# Altium schematic sheet USB Hub IC.SchDoc("USB Hub IC")
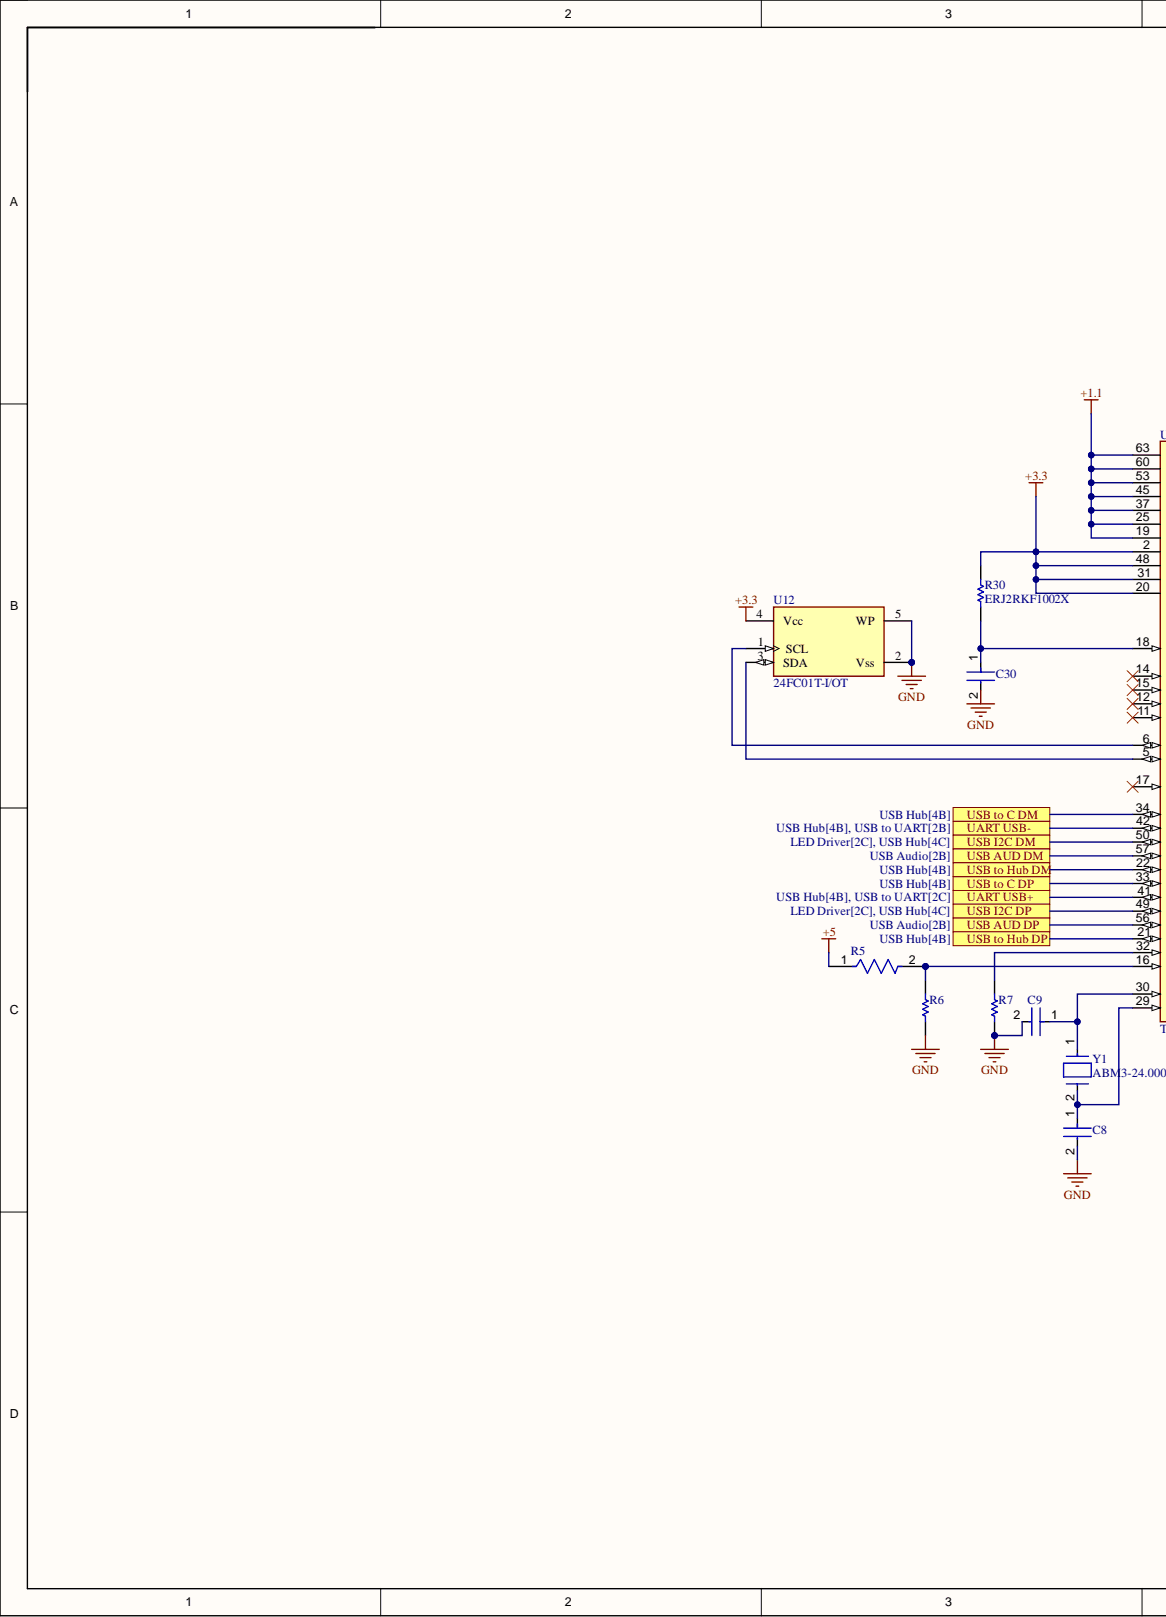
[diagram p0: USB Hub IC.SchDoc, left]
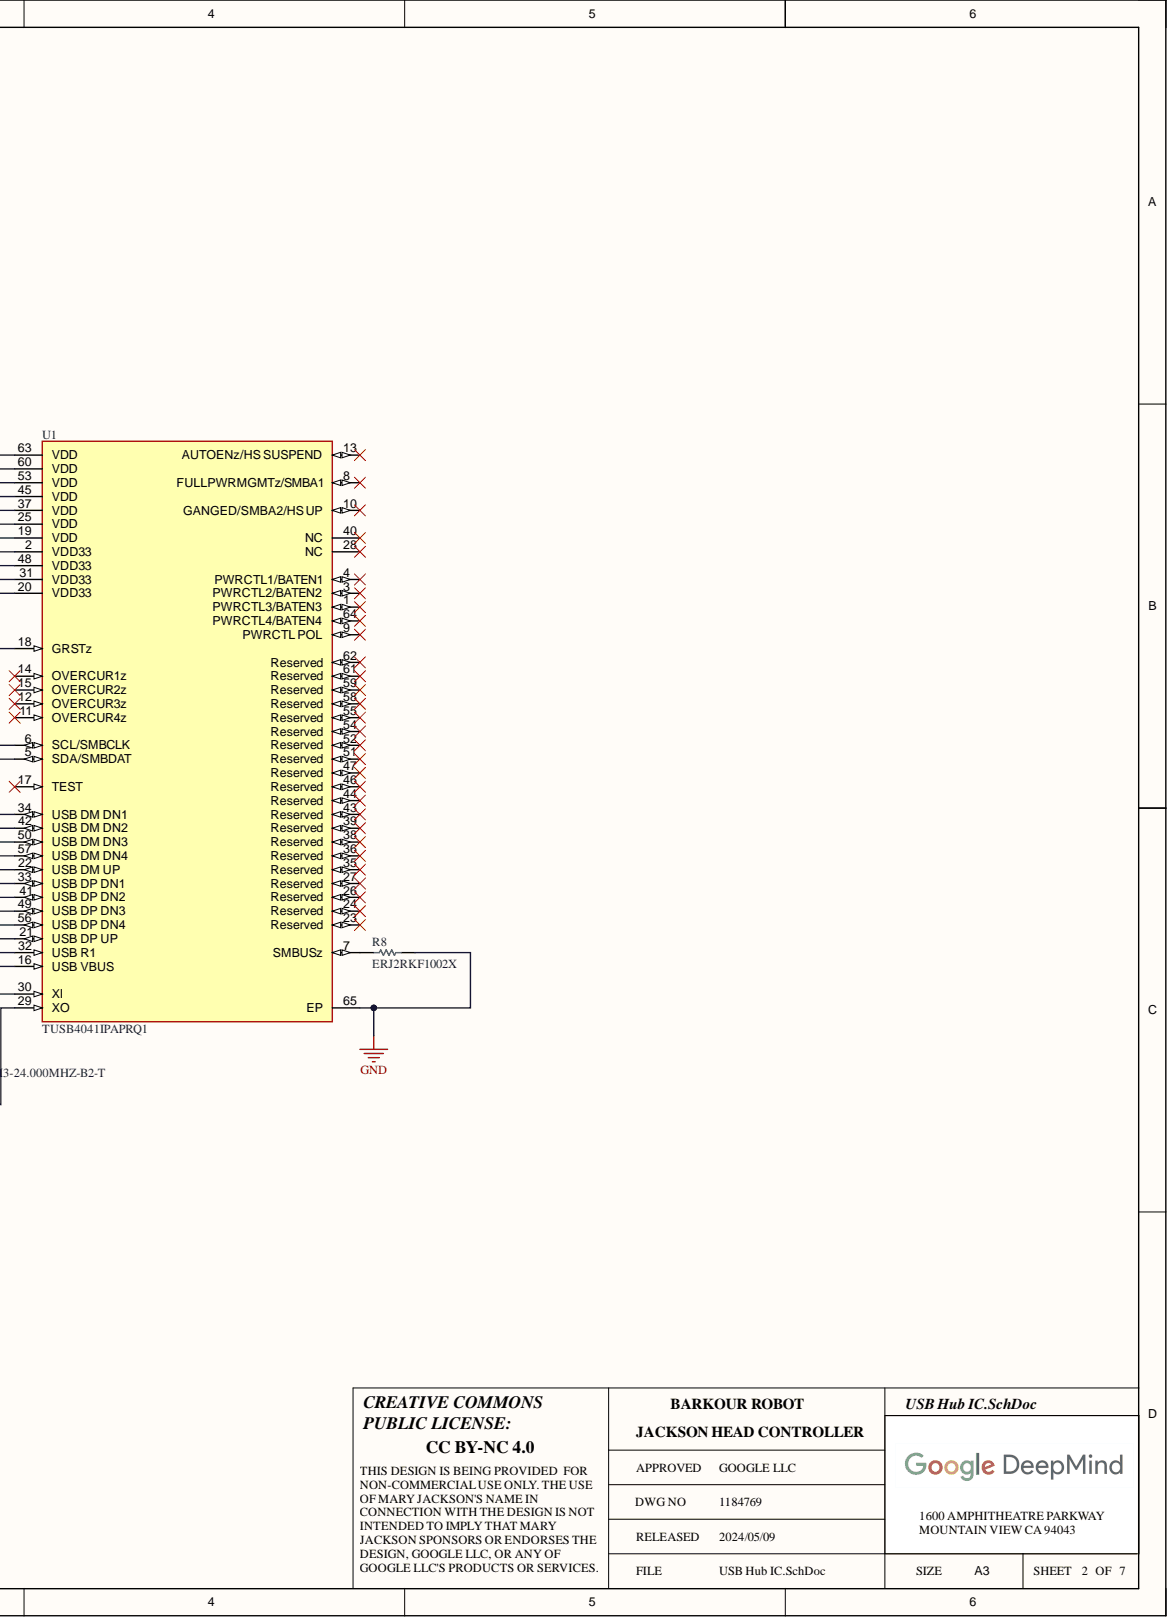
[diagram p1: USB Hub IC.SchDoc, right]

## Parts
C8  GJM1555C1H180JB01D  [FP-GJM155-0_05-IPC_B]  (value not drawn)
C9  GJM1555C1H180JB01D  [FP-GJM155-0_05-IPC_B]  (value not drawn)
C30  GRM1555C1E103GE01D  [FP-GRM155-0_15-IPC_B]  (value not drawn)
R5  ERJ-2RKF9092X  [FP-ERJ2RK-MFG]  (value not drawn)
R6  ERJ2RKF1002X  [RESC1005X40X25LL05T05]
R7  ERA-3AED9531V  [RESC1608X55X30ML20T20]  (value not drawn)
R8  ERJ2RKF1002X  [RESC1005X40X25LL05T05]
R30  ERJ2RKF1002X  [RESC1005X40X25LL05T05]
U1  TUSB4041IPAPRQ1  [FP-PAP0064K-MFG]
U12  24FC01T-I/OT  [FP-C04-091-OT-MFG]
Y1  ABM3-24.000MHZ-B2-T  [FP-ABM3-MFG]

## Ports
UART USB+, UART USB-, USB AUD DM, USB AUD DP, USB I2C DM, USB I2C DP, USB to C DM, USB to C DP, USB to Hub DM, USB to Hub DP

## Nets
+1.1: U1.19(VDD) U1.25(VDD) U1.37(VDD) U1.45(VDD) U1.53(VDD) U1.60(VDD) U1.63(VDD)
+3.3: R30.2 U1.2(VDD33) U1.20(VDD33) U1.31(VDD33) U1.48(VDD33) U12.4(Vcc)
+5: R5.1
GND: C8.2 C9.2 C30.2 R6.1 R7.1 R8.1 U1.65(EP) U12.2(Vss) U12.5(WP)
USB AUD DM: U1.57(USB_DM_DN4) ; port USB AUD DM
USB AUD DP: U1.56(USB_DP_DN4) ; port USB AUD DP
USB I2C DM: U1.50(USB_DM_DN3) ; port USB I2C DM
USB I2C DP: U1.49(USB_DP_DN3) ; port USB I2C DP
USB to C DM: U1.34(USB_DM_DN1) ; port USB to C DM
USB to C DP: U1.33(USB_DP_DN1) ; port USB to C DP
USB to Hub DM: U1.22(USB_DM_UP) ; port USB to Hub DM
USB to Hub DP: U1.21(USB_DP_UP) ; port USB to Hub DP
NetC8_1: C8.1 U1.29(XO) Y1.2
NetC9_1: C9.1 U1.30(XI) Y1.1
NetC30_1: C30.1 R30.1 U1.18(GRSTz)
NetR5_2: R5.2 R6.2 U1.16(USB_VBUS)
NetR7_2: R7.2 U1.32(USB_R1)
NetR8_2: R8.2 U1.7(SMBUSz)
NetU1_5: U1.5(SDA/SMBDAT) U12.3(SDA)
NetU1_6: U1.6(SCL/SMBCLK) U12.1(SCL)
NetU1_41: U1.41(USB_DP_DN2)
NetU1_42: U1.42(USB_DM_DN2)

Not connected: U1 1(PWRCTL3/BATEN3) 3(PWRCTL2/BATEN2) 4(PWRCTL1/BATEN1) 8(FULLPWRMGMTz/SMBA1) 9(PWRCTL_POL) 10(GANGED/SMBA2/HS_UP) 11(OVERCUR4z) 12(OVERCUR3z) 13(AUTOENz/HS_SUSPEND) 14(OVERCUR1z) 15(OVERCUR2z) 17(TEST) 23(Reserved) 24(Reserved) 26(Reserved) 27(Reserved) 28(NC) 35(Reserved) 36(Reserved) 38(Reserved) 39(Reserved) 40(NC) 43(Reserved) 44(Reserved) 46(Reserved) 47(Reserved) 51(Reserved) 52(Reserved) 54(Reserved) 55(Reserved) 58(Reserved) 59(Reserved) 61(Reserved) 62(Reserved) 64(PWRCTL4/BATEN4)

## Library data of the parts
C8 C9 = CMP-2008-03807-2: Multi-Layer Ceramic Capacitor 18pF C0G  ±5% 0402 Paper T/R; Voltage: 50V; Capacitance: 18pF; Case Code (Imperial): 0402; Case Code (Metric): 1005; Case/Package: 0402; Component Kind: Standard; Depth: 0.5mm; Dielectric: C0G; Height: 0.5mm; Lead Free: Lead Free; Length: 1mm; Library Name: Altium Content Vault; Max Operating Temperature: 125°C; Min Operating Temperature: -55°C; Mount: Surface Mount; Package Quantity: 1; Packaging: Tape and Reel; Radiation Hardening: No; Termination: SMD/SMT; Thickness: 0.022inch; Tolerance: 5%; Voltage Rating: 50V; Voltage Rating (DC): 50V; Width: 0.02inch
C30 = CMP-06035-007392-1: Chip Multilayer Ceramic Capacitors for General Purpose, 0402, 10000pF, C0G, 30ppm/°C, 2%, 25V; Component Kind: Standard; Library Name: Altium Content Vault
R5 = CMP-1011-00731-3: RES SMD 90.9K OHM 1% 1/10W 0402; Max Power Dissipation: 100mW; Resistance: 90.9kR; Temperature Coefficient: 100ppm/°C; Voltage Rating: 50V; Case Code (Imperial): 0402; Case Code (Metric): 1005; Case/Package: 0402; Component Kind: Standard; Construction: Rectangular; Contact Plating: Tin; Depth: 0.5mm; Features: Automotive AEC-Q200; Height: 0.016inch; Length: 1mm; Library Name: Altium Content Vault; Max Operating Temperature: 155°C; Military Standard: Not; Min Operating Temperature: -55°C; Mount: Surface Mount; Number of Terminations: 2; Package Quantity: 1; Packaging: Tape and Reel; Power Rating: 100mW; REACH SVHC: No SVHC; Resistance Tolerance: 1%; Resistor Type: Thick Film; RoHS Compliant: Yes; Series: ERJ; Termination: SMD/SMT; Tolerance: 1%; Voltage Rating (DC): 50V; Width: 0.02inch
R6 R8 R30 = CMP-2000-07588-1: Chip Resistor, 10 KOhm, +/- 1%, 0.1 W, -55 to 155 degC, 0402 (1005 Metric), RoHS, Tape and Reel; Manufacturer: Panasonic; Case\/Package: 0402; Max Power Dissipation: 100mW; Resistance: 10KOhm; Temperature Coefficient: 100ppm\/degreesC; Voltage Rating: 50V; Case Code (Imperial): 0402; Case Code (Metric): 1005; Component Kind: Standard; Composition: ThickFilm; Contact Plating: Tin; Datasheet URL: https://industrial.panasonic.com/cdbs/www-data/pdf/RDA0000/AOA0000C304.pdf; Datasheet Version: 02/2017; Depth: 0.5mm; Height: 0.35mm; Lead Free: LeadFree; Length: 1mm; Library Name: Altium Content Vault; Manufacturer URL: http://www.panasonic.com/; Max Operating Temperature: 155degC; Military Standard: Not; Min Operating Temperature: -55degC; Mount: SurfaceMount; Mounting Technology: SurfaceMount; Number of Pins: 2; Number of Terminations: 2; Package Description: 2-Pin Surface Mount Device, Body 1 x 0.5 mm; Package Quantity: 1; Package Reference: 402; Packaging: TapeandReel; Power Rating: 100mW; Radiation Hardening: No; REACH SVHC: NoSVHC; RoHSCompliant: True; Termination: SMD/SMT; Tolerance: 1%; Voltage Rating (DC): 50V; Width: 0.02inch
R7 = CMP-2000-00160-1: Manufacturer: Panasonic; Component Kind: Standard; Library Name: Altium Content Vault; Part Number: ERA-3AED9531V
U1 = CMP-04877-000003-1: IC USB 2.0 4PORT HUB 64HTQFP; Manufacturer: Texas Instruments; Data Rate: 480Mbits/s; Interface: I2C,USB,SMBus; Max Junction Temp: +105°C; Max Supply Voltage: 3.6V; Min Supply Voltage: 0.99V; Nominal Supply Current: 15uA; Number Of Ports: 4; Standoff Height: 0.05mm; Usb Standard: USB 2.0; Ambient Temperature Range High: +85°C; Ambient Temperature Range Low: -40°C; Automotive: No; Category: IC; Component Kind: Standard; ComponentLink2Description: Datasheet; ComponentLink2URL: http://www.ti.com/lit/ds/symlink/tusb4041i.pdf; Device Class L1: Integrated Circuits (ICs); Device Class L2: Interface ICs; Device Class L3: USB Interface ICs; Digikey Description: IC USB 2.0 4PORT HUB 64HTQFP; Height: 1.2mm; Ipc Land Pattern Name: QFP50P1200X1200X120-64; Lead Free: Yes; Library Name: Altium Content Vault; Manufacturer Part Number: TUSB4041IPAPR; Octopart: https://octopart.com/tusb4041ipapr-texas+instruments-61734371; Package: PAP0064K; RoHS: TRUE; Temperature: -40°C to +85°C; Temperature Range High: +85°C; Temperature Range Low: -40°C
U12 = CMP-06313-000176-1: IC EEPROM 1KBIT I2C 1MHZ SOT23-5; Access Time: 450ns; Memory Size: 1Kb; Memory Type: Non-Volatile; Case/Package: SOT-23-5; Component Kind: Standard; Frequency: 1MHz; Library Name: Altium Content Vault; Max Operating Temperature: 85°C; Min Operating Temperature: -40°C; Mount: Surface Mount; Packaging: Cut Tape; RoHS Compliant: Yes; Series: -
Y1 = CMP-27762-013604-1: Crystal 24MHz ±20ppm 18pF SMD-2 5mm x 3.2mm; ESR (Equivalent Series Resistance): 30R; Frequency Stability: 50ppm; Series Resistance: 50R; Accuracy: 20ppm; Case/Package: CSMD; Component Kind: Standard; Depth: 3.2mm; Frequency: 24MHz; Frequency Tolerance: 20ppm; Height: 0.051inch; Lead Free: Lead Free; Length: 5mm; Library Name: Altium Content Vault; Load Capacitance: 18pF; Max Operating Temperature: 70°C; Min Operating Temperature: -20°C; Mount: Surface Mount; Number of Pins: 2; Operating Frequency: 24 MHz; Package Quantity: 1000; Packaging: Tape and Reel; Radiation Hardening: No; REACH SVHC: Yes; Resistor Type: Ceramic; Series: ABM3; Termination: SMD/SMT; Tolerance: 20ppm; Width: 3.2mm
